# Altium schematic sheet SMALL_BCM.SchDoc
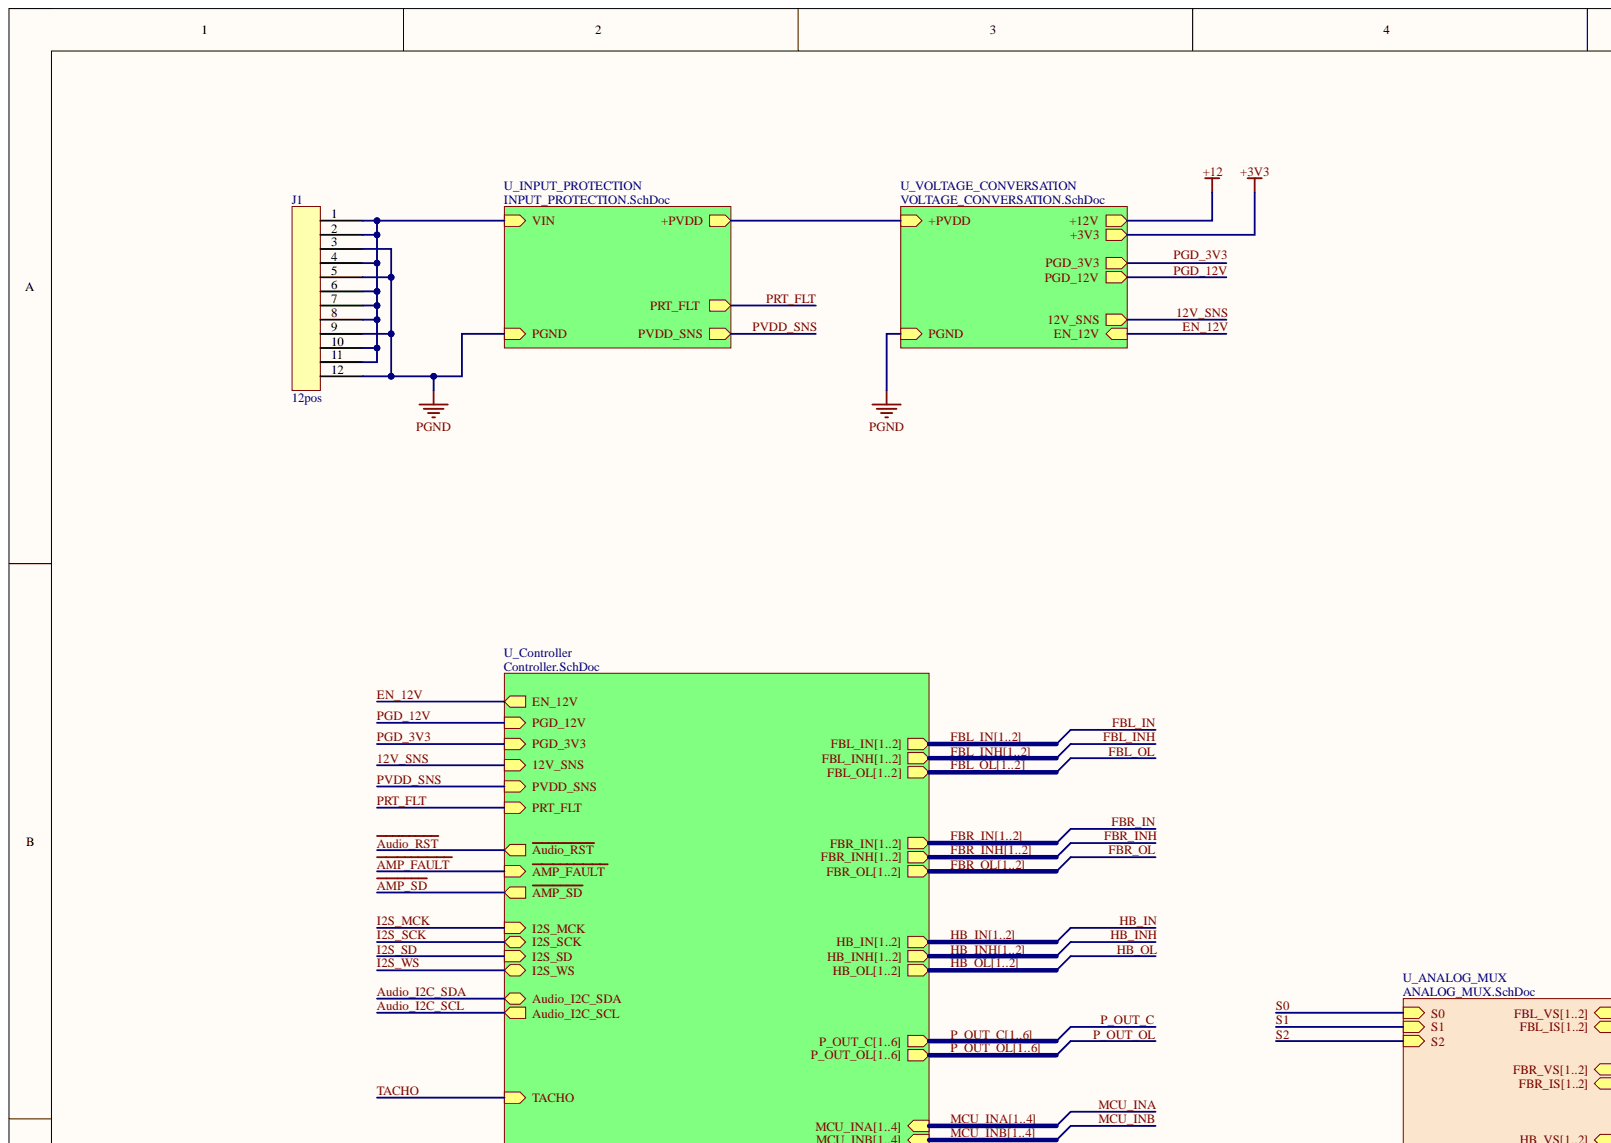
[diagram p0: SMALL_BCM.SchDoc, top left]
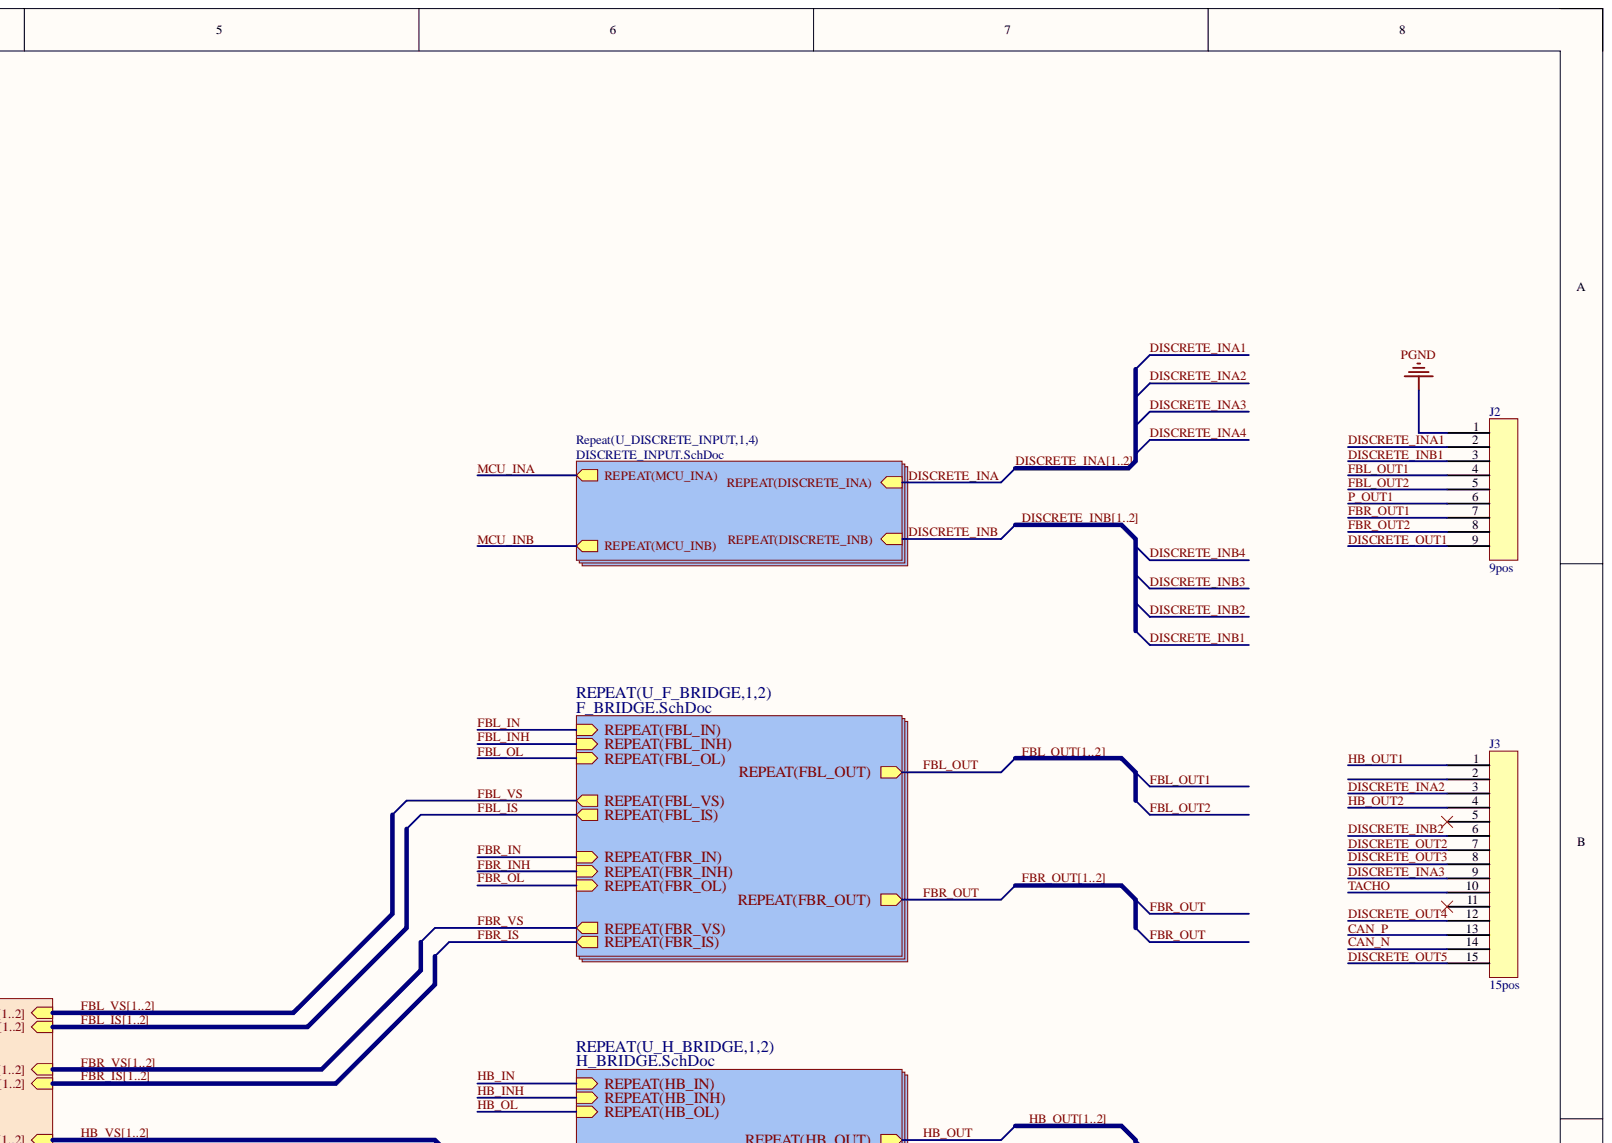
[diagram p1: SMALL_BCM.SchDoc, top right]
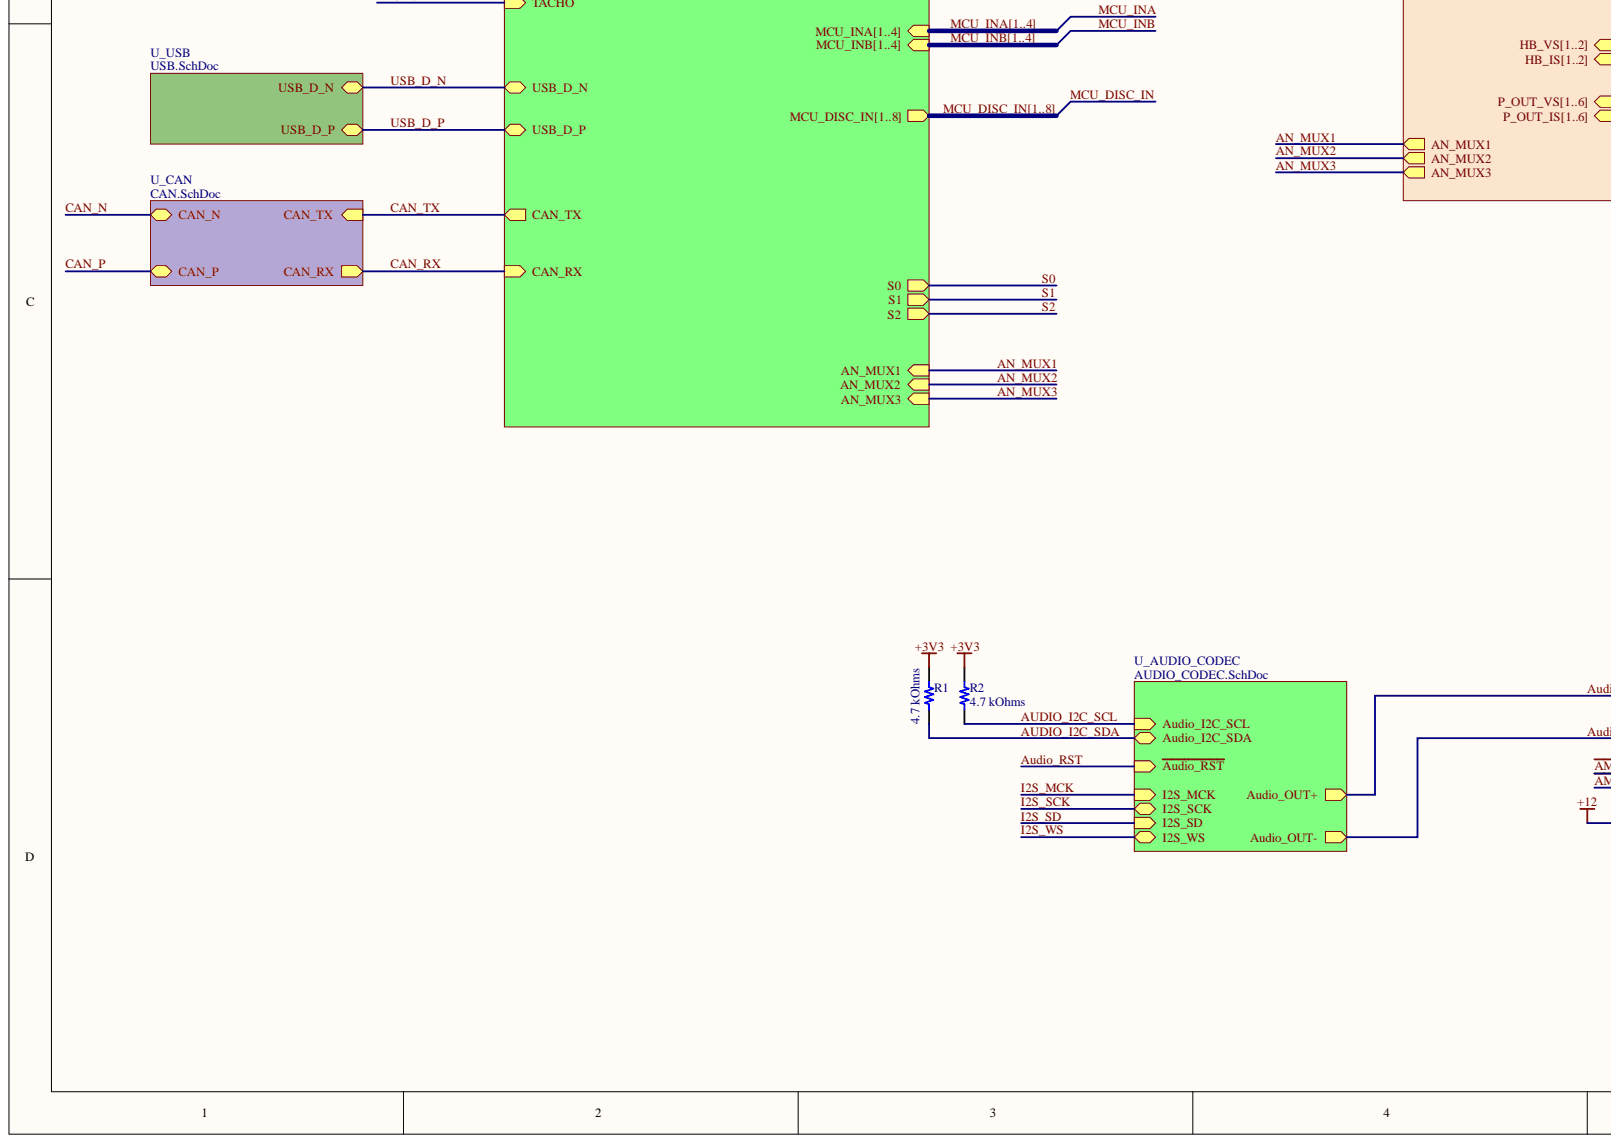
[diagram p2: SMALL_BCM.SchDoc, bottom left]
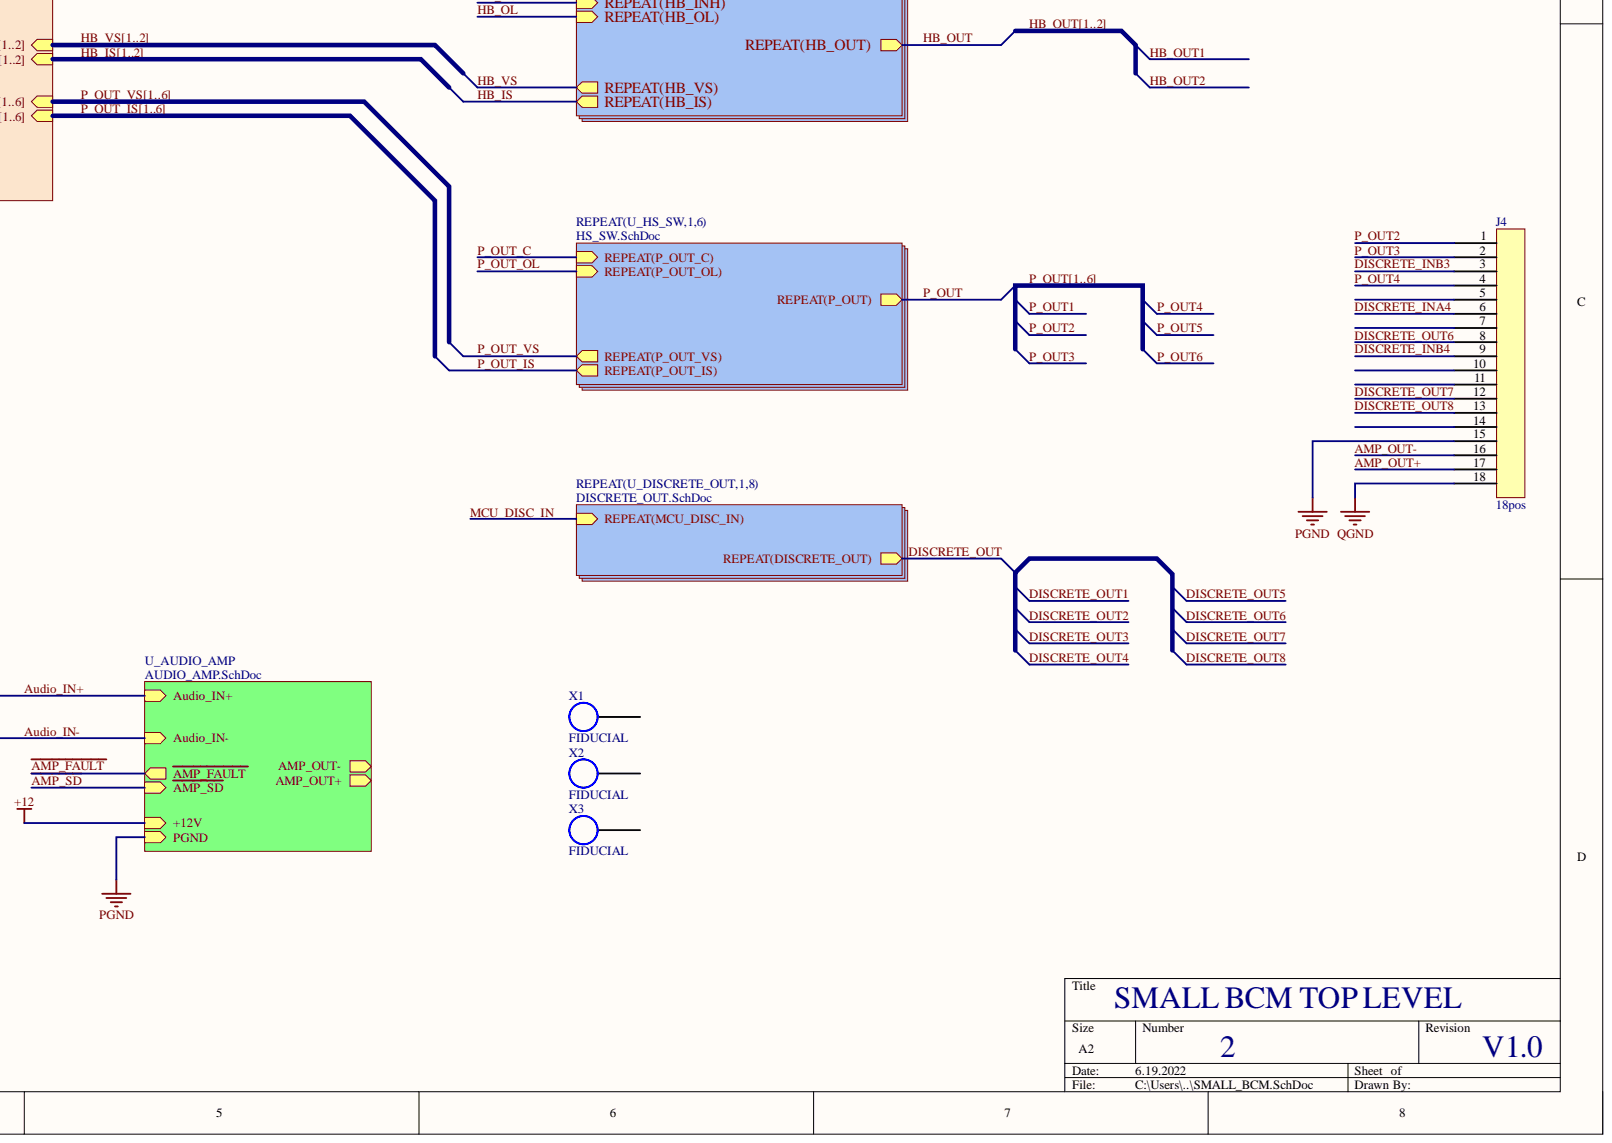
[diagram p3: SMALL_BCM.SchDoc, bottom right]

## Parts
J1  12pos  [9-966140-3]
J2  9pos  [9-966140-4]
J3  15pos  [9-966140-2]
J4  18pos  [9-966140-1]
R1  4.7 kOhms  [RES 0603_1608]
R2  4.7 kOhms  [RES 0603_1608]
X1  FIDUCIAL  [FIDUCIAL]  (no BOM)
X2  FIDUCIAL  [FIDUCIAL]  (no BOM)
X3  FIDUCIAL  [FIDUCIAL]  (no BOM)

## Nets
+3V3: R1.2 R2.1
PGND: J1.3 J1.5 J1.9 J1.12 J2.1 J4.15
QGND: J4.18
AMP_OUT+: J4.17
AMP_OUT-: J4.16
Audio_I2C_SCL: R2.2
Audio_I2C_SDA: R1.1
CAN_N: J3.14
CAN_P: J3.13
DISCRETE_INA1: J2.2  [also labelled DISCRETE_INA]
DISCRETE_INA2: J3.3  [also labelled DISCRETE_INA]
DISCRETE_INA3: J3.9
DISCRETE_INA4: J4.6
DISCRETE_INB1: J2.3  [also labelled DISCRETE_INB]
DISCRETE_INB2: J3.6  [also labelled DISCRETE_INB]
DISCRETE_INB3: J4.3
DISCRETE_INB4: J4.9
DISCRETE_OUT1: J2.9
DISCRETE_OUT2: J3.7
DISCRETE_OUT3: J3.8
DISCRETE_OUT4: J3.12
DISCRETE_OUT5: J3.15
DISCRETE_OUT6: J4.8
DISCRETE_OUT7: J4.12
DISCRETE_OUT8: J4.13
FBL_OUT1: J2.4  [also labelled FBL_OUT]
FBL_OUT2: J2.5  [also labelled FBL_OUT]
FBR_OUT1: J2.7  [also labelled FBR_OUT]
FBR_OUT2: J2.8  [also labelled FBR_OUT]
HB_OUT1: J3.1  [also labelled HB_OUT]
HB_OUT2: J3.4  [also labelled HB_OUT]
P_OUT1: J2.6  [also labelled P_OUT]
P_OUT2: J4.1  [also labelled P_OUT]
P_OUT3: J4.2  [also labelled P_OUT]
P_OUT4: J4.4  [also labelled P_OUT]
TACHO: J3.10
NetJ1_1: J1.1 J1.2 J1.4 J1.6 J1.7 J1.8 J1.10 J1.11
NetJ3_2: J3.2
NetJ3_5: J3.5
NetJ3_11: J3.11
NetJ4_5: J4.5
NetJ4_7: J4.7
NetJ4_10: J4.10
NetJ4_11: J4.11
NetJ4_14: J4.14
NetX1_1: X1.1
NetX2_1: X2.1
NetX3_1: X3.1
12V_SNS:
+PVDD:
~{AMP_FAULT}:
~{AMP_SD}:
~{Audio_RST}:
AN_MUX1:
AN_MUX2:
AN_MUX3:
Audio_IN+:
Audio_IN-:
Audio_RST:
CAN_RX:
CAN_TX:
EN_12V:
FBL_IN:
FBL_IN1:  [also labelled FBL_IN]
FBL_IN2:  [also labelled FBL_IN]
FBL_INH:
FBL_INH1:  [also labelled FBL_INH]
FBL_INH2:  [also labelled FBL_INH]
FBL_IS1:  [also labelled FBL_IS]
FBL_IS2:  [also labelled FBL_IS]
FBL_OL:
FBL_OL1:  [also labelled FBL_OL]
FBL_OL2:  [also labelled FBL_OL]
FBL_VS1:  [also labelled FBL_VS]
FBL_VS2:  [also labelled FBL_VS]
FBR_IN:
FBR_IN1:  [also labelled FBR_IN]
FBR_IN2:  [also labelled FBR_IN]
FBR_INH:
FBR_INH1:  [also labelled FBR_INH]
FBR_INH2:  [also labelled FBR_INH]
FBR_IS1:  [also labelled FBR_IS]
FBR_IS2:  [also labelled FBR_IS]
FBR_OL:
FBR_OL1:  [also labelled FBR_OL]
FBR_OL2:  [also labelled FBR_OL]
FBR_OUT:
FBR_VS1:  [also labelled FBR_VS]
FBR_VS2:  [also labelled FBR_VS]
HB_IN:
HB_IN1:  [also labelled HB_IN]
HB_IN2:  [also labelled HB_IN]
HB_INH:
HB_INH1:  [also labelled HB_INH]
HB_INH2:  [also labelled HB_INH]
HB_IS1:  [also labelled HB_IS]
HB_IS2:  [also labelled HB_IS]
HB_OL:
HB_OL1:  [also labelled HB_OL]
HB_OL2:  [also labelled HB_OL]
HB_VS1:  [also labelled HB_VS]
HB_VS2:  [also labelled HB_VS]
I2S_MCK:
I2S_SCK:
I2S_SD:
I2S_WS:
MCU_DISC_IN:
MCU_DISC_IN1:  [also labelled MCU_DISC_IN]
MCU_DISC_IN2:  [also labelled MCU_DISC_IN]
MCU_DISC_IN3:  [also labelled MCU_DISC_IN]
MCU_DISC_IN4:  [also labelled MCU_DISC_IN]
MCU_DISC_IN5:  [also labelled MCU_DISC_IN]
MCU_DISC_IN6:  [also labelled MCU_DISC_IN]
MCU_DISC_IN7:  [also labelled MCU_DISC_IN]
MCU_DISC_IN8:  [also labelled MCU_DISC_IN]
MCU_INA:
MCU_INA1:  [also labelled MCU_INA]
MCU_INA2:  [also labelled MCU_INA]
MCU_INA3:  [also labelled MCU_INA]
MCU_INA4:  [also labelled MCU_INA]
MCU_INB:
MCU_INB1:  [also labelled MCU_INB]
MCU_INB2:  [also labelled MCU_INB]
MCU_INB3:  [also labelled MCU_INB]
MCU_INB4:  [also labelled MCU_INB]
P_OUT5:  [also labelled P_OUT]
P_OUT6:  [also labelled P_OUT]
P_OUT_C:
P_OUT_C1:  [also labelled P_OUT_C]
P_OUT_C2:  [also labelled P_OUT_C]
P_OUT_C3:  [also labelled P_OUT_C]
P_OUT_C4:  [also labelled P_OUT_C]
P_OUT_C5:  [also labelled P_OUT_C]
P_OUT_C6:  [also labelled P_OUT_C]
P_OUT_IS1:  [also labelled P_OUT_IS]
P_OUT_IS2:  [also labelled P_OUT_IS]
P_OUT_IS3:  [also labelled P_OUT_IS]
P_OUT_IS4:  [also labelled P_OUT_IS]
P_OUT_IS5:  [also labelled P_OUT_IS]
P_OUT_IS6:  [also labelled P_OUT_IS]
P_OUT_OL:
P_OUT_OL1:  [also labelled P_OUT_OL]
P_OUT_OL2:  [also labelled P_OUT_OL]
P_OUT_OL3:  [also labelled P_OUT_OL]
P_OUT_OL4:  [also labelled P_OUT_OL]
P_OUT_OL5:  [also labelled P_OUT_OL]
P_OUT_OL6:  [also labelled P_OUT_OL]
P_OUT_VS1:  [also labelled P_OUT_VS]
P_OUT_VS2:  [also labelled P_OUT_VS]
P_OUT_VS3:  [also labelled P_OUT_VS]
P_OUT_VS4:  [also labelled P_OUT_VS]
P_OUT_VS5:  [also labelled P_OUT_VS]
P_OUT_VS6:  [also labelled P_OUT_VS]
PGD_3V3:
PGD_12V:
PRT_FLT:
PVDD_SNS:
S0:
S1:
S2:
USB_D_N:
USB_D_P:

## Library data of the parts
J1 = 3x4_9-966140-3: CONN HEADER R/A 12POS 5MM; Order Code: DIGI : A126711-ND; Component Kind: Standard; P/N: 9-966140-3; Value: 12pos; Library Name: SMALL_BCM.IntLib
J2 = 3x4_9-966140-4: CONN HEADER R/A 9POS 5MM; Order Code: DIGI : A122202-ND; Component Kind: Standard; Library Name: ECU.IntLib; P/N: 9-966140-4; Value: 9pos
J3 = 3x5_9-966140-2: CONN HEADER R/A 15POS 5MM; Order Code: DIGI : A126710-ND; Component Kind: Standard; Library Name: ECU.IntLib; P/N: 9-966140-2; Value: 15pos
J4 = 3x6_9-966140-1: CONN HEADER R/A 18POS 5MM; Order Code: DIGI : A121600-ND; Component Kind: Standard; Library Name: ECU.IntLib; P/N: 9-966140-1; Value: 18pos
R1 R2 = RK73B1JTTD472J: RES 4.7K OHM 5% 1/10W 0603; Manufacturer: KOA Speer Electronics, Inc.; Database Table Name: Resistors - Surface Mount - 0603; Power (Watts): 0.1W, 1/10W; Temperature Coefficient: ±200ppm/°C; Component Kind: Standard; ComponentLink1Description: Datasheet; ComponentLink1URL: http://www.koaspeer.com/catimages/Products/RK73B/RK73B.pdf; ComponentLink2Description: DigiKey Link; ComponentLink2URL: https://www.digikey.com/en/products/detail/koa-speer-electronics-inc/RK73B1JTTD472J/9844699; Composition: Thick Film; Device Package: 0603 (1608 Metric); Features: Automotive AEC-Q200; Height - Seated (Max): 0.022" (0.55mm); LastUpdated: 18.06.2022 06:14:08; Library Name: Celestial Altium Library.DbLib; Lifecycle Status: Active; Minimum Order: 0; Number of Terminations: 2; Operating Temperature: -55°C ~ 155°C; Package / Case: 0603 (1608 Metric); Part Number: RK73B1JTTD472J; Part Status: Active; PartId: 5830; Price: 0; Ratings: AEC-Q200; Resistance: 4.7 kOhms; Series: RK73B; Size / Dimension: 0.063" L x 0.031" W (1.60mm x 0.80mm); Supplier 1: DigiKey; Supplier Device Package: 0603; Supplier Part Number 1: 2019-RK73B1JTTD472JTR-ND; Tolerance: ±5%; Value: 4.7 kOhms
X1-X3 = FIDUCIAL: FIDUCIAL 1mm; Library Name: MISC_Library.SchLib
